# Altium schematic sheet PhantomPowerSupply_Schematic.SchDoc
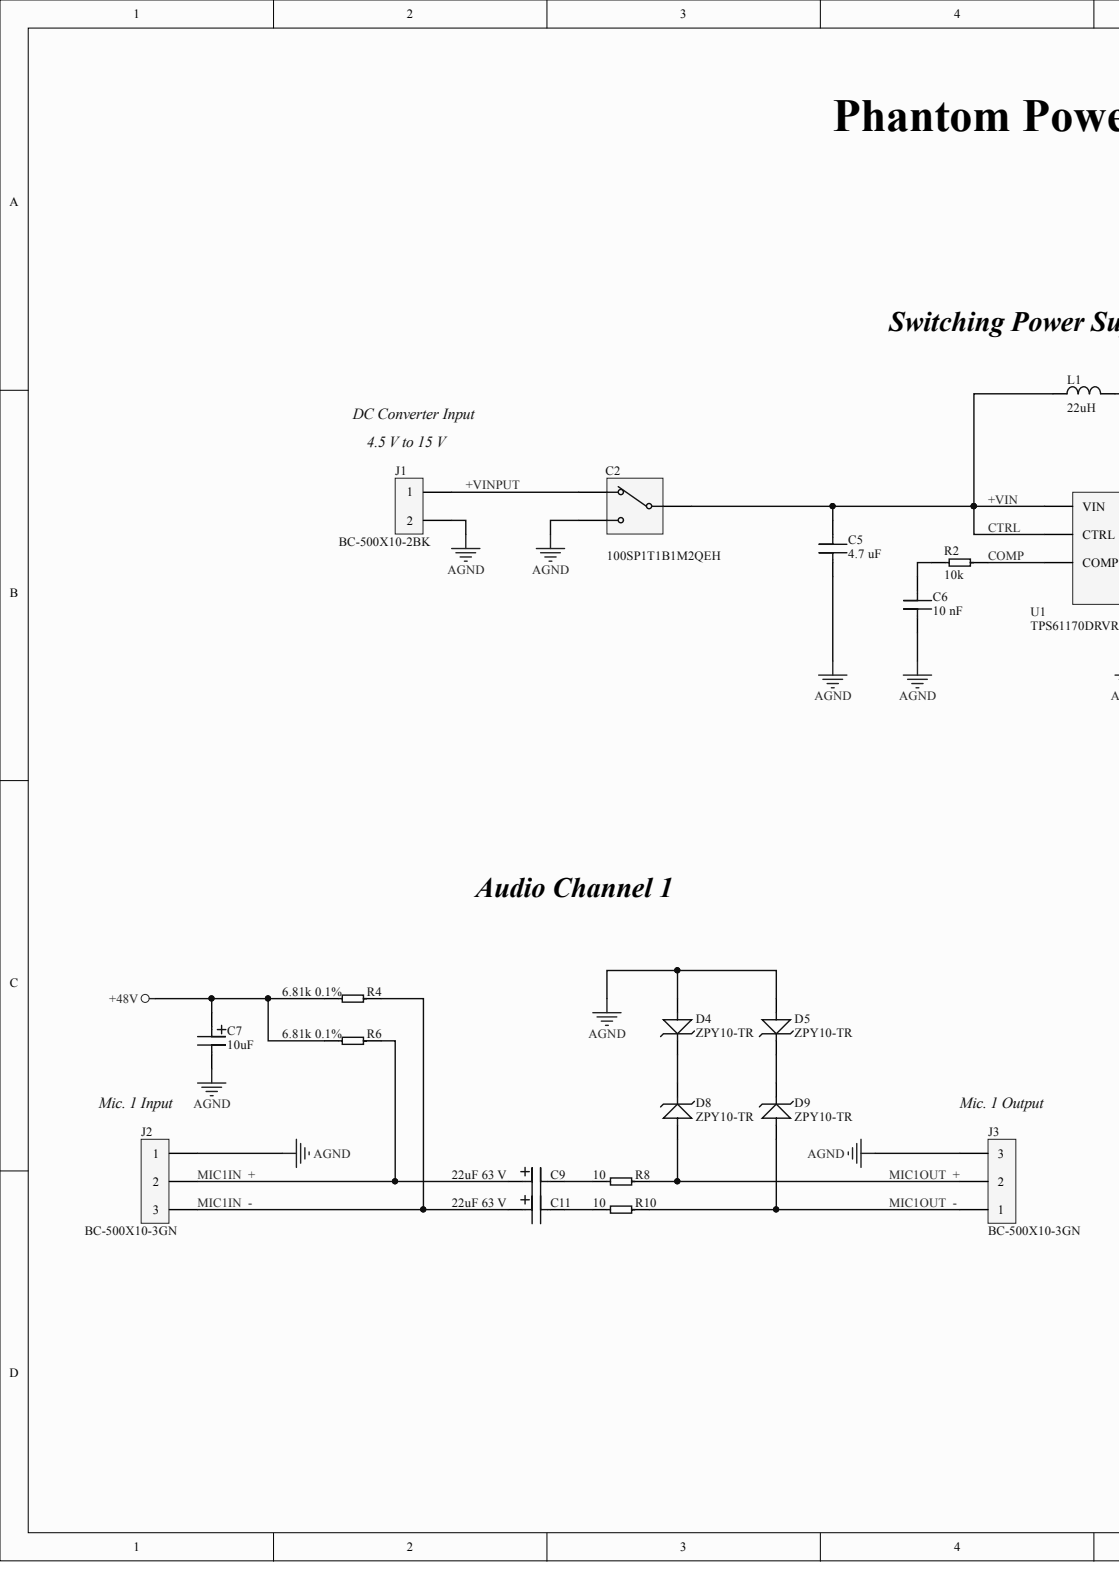
[diagram p0: PhantomPowerSupply_Schematic.SchDoc, left]
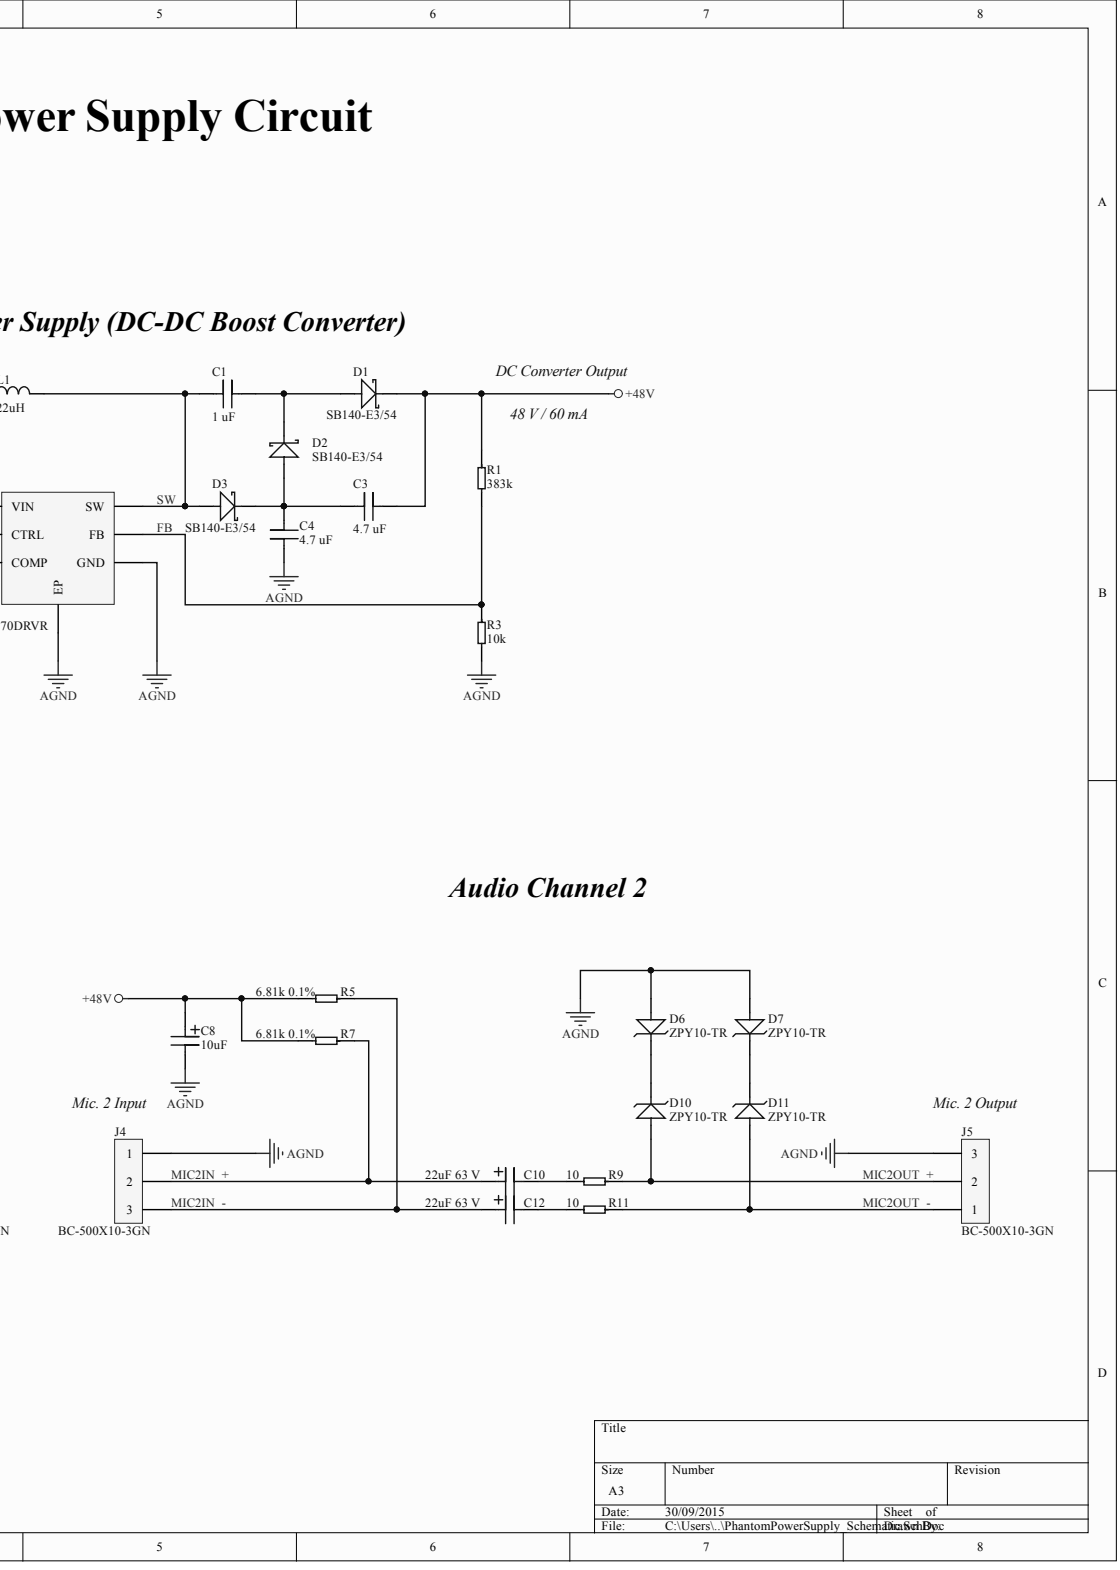
[diagram p1: PhantomPowerSupply_Schematic.SchDoc, right]

## Parts
C1  1 uF  [CRADIAL-CERAMIC-BROWN]
C2  100SP1T1B1M2QEH  [SPDT-M2]
C3  4.7 uF  [CRADIAL-CERAMIC]
C4  4.7 uF  [CRADIAL-CERAMIC]
C5  4.7 uF  [CRADIAL-CERAMIC]
C6  10 nF  [CRADIAL-CERAMIC-BROWN]
C7  10uF  [C-ELECTROLYTIC-RADIAL]
C8  10uF  [C-ELECTROLYTIC-RADIAL]
C9  22uF 63 V  Voltage Rating DC: 63 V  [C-ELECTROLYTIC-RADIAL]
C10  22uF 63 V  Voltage Rating DC: 63 V  [C-ELECTROLYTIC-RADIAL]
C11  22uF 63 V  Voltage Rating DC: 63 V  [C-ELECTROLYTIC-RADIAL]
C12  22uF 63 V  Voltage Rating DC: 63 V  [C-ELECTROLYTIC-RADIAL]
D1  SB140-E3/54  [D-SCHOTTKY-AXIAL]
D2  SB140-E3/54  [D-SCHOTTKY-AXIAL]
D3  SB140-E3/54  [D-SCHOTTKY-AXIAL]
D4  ZPY10-TR  [D-ZENER-AXIAL]
D5  ZPY10-TR  [D-ZENER-AXIAL]
D6  ZPY10-TR  [D-ZENER-AXIAL]
D7  ZPY10-TR  [D-ZENER-AXIAL]
D8  ZPY10-TR  [D-ZENER-AXIAL]
D9  ZPY10-TR  [D-ZENER-AXIAL]
D10  ZPY10-TR  [D-ZENER-AXIAL]
D11  ZPY10-TR  [D-ZENER-AXIAL]
J1  BC-500X10-2BK  [SCREW-CON-2POS]
J2  BC-500X10-3GN  [SCREW-CON-3POS]
J3  BC-500X10-3GN  [SCREW-CON-3POS]
J4  BC-500X10-3GN  [SCREW-CON-3POS]
J5  BC-500X10-3GN  [SCREW-CON-3POS]
L1  22uH  [L-AXIAL]
R1  383k  [R-AXIAL]
R2  10k  [R-AXIAL]
R3  10k  [R-AXIAL]
R4  6.81k 0.1%  [R-AXIAL]
R5  6.81k 0.1%  [R-AXIAL]
R6  6.81k 0.1%  [R-AXIAL]
R7  6.81k 0.1%  [R-AXIAL]
R8  10  [R-AXIAL]
R9  10  [R-AXIAL]
R10  10  [R-AXIAL]
R11  10  [R-AXIAL]
U1  TPS61170DRVR  [S-PWSON-N6]

## Nets
+48V: C3.2 C7.1 C8.1 D1.2 R1.2 R4.1 R5.1 R6.1 R7.1
AGND: C2.3 C4.1 C5.1 C6.1 C7.2 C8.2 D4.1 D5.1 D6.1 D7.1 J1.2 J2.1 J3.3 J4.1 J5.3 R3.1 U1.3(GND) U1.7(EP)
+VIN: C2.2 C5.2 L1.1 U1.5(CTRL) U1.6(VIN)
+VINPUT: C2.1 J1.1
COMP: R2.2 U1.2(COMP)
FB: R1.1 R3.2 U1.1(FB)
MIC1IN_+: C9.1 J2.2 R6.2
MIC1IN_-: C11.1 J2.3 R4.2
MIC1OUT_+: D8.1 J3.2 R8.2
MIC1OUT_-: D9.1 J3.1 R10.2
MIC2IN_+: C10.1 J4.2 R7.2
MIC2IN_-: C12.1 J4.3 R5.2
MIC2OUT_+: D10.1 J5.2 R9.2
MIC2OUT_-: D11.1 J5.1 R11.2
SW: C1.1 D3.1 L1.2 U1.4(SW)
NetC1_2: C1.2 D1.1 D2.2
NetC3_1: C3.1 C4.2 D2.1 D3.2
NetC6_2: C6.2 R2.1
NetC9_2: C9.2 R8.1
NetC10_2: C10.2 R9.1
NetC11_2: C11.2 R10.1
NetC12_2: C12.2 R11.1
NetD4_2: D4.2 D8.2
NetD5_2: D5.2 D9.2
NetD6_2: D6.2 D10.2
NetD7_2: D7.2 D11.2

## Library data of the parts
C1 = K105K20X7RF5TH5: Multilayer Ceramic Capacitors MLCC - Leaded 1uF 50volts 10% X7R 5mm LS; Brand: Vishay / BC Components; Case Style: Dipped; Lead Diameter: 0.5 mm; Voltage Rating: 50 V; Capacitance: 1 uF; Case Height: 5 mm; Case Length: 5 mm; Case Width: 5 mm; Category: Multilayer Ceramic Capacitors MLCC - Leaded; Component Kind: Standard; ComponentLink1Description: http://www.mouser.com/ds/2/427/mkseries-205465.pdf; ComponentLink1URL: http://www.mouser.com/ds/2/427/mkseries-205465.pdf; Lead Spacing: 5 mm; Lead Style: Outside Bend; Manufacturer: Vishay / BC Components; Manufacturer Part Number: K105K20X7RF5TH5; Maximum Operating Temperature: + 125 C; Minimum Operating Temperature: - 55 C; Minimum Order Quantity: 1; Operating Temperature Range: - 55 C to + 125 C; Packaging: Reel; Pricing 1: 1=0.312, 3000=0.166, 6000=0.150, 15000=0.141, 30000=0.131 (EUR); Product: General Type MLCCs; Product Category: Ceramic Capacitors; Reeling: True; RoHS: RoHS Compliant By Exemption; RoHS - Mouser: E; Series: K; Stock 1: 11553; Supplier 1: Mouser; Temperature Coefficient/Code: X7R; Termination Style: Radial; Tolerance: 10 %; Tradename: Mono-Kap; Voltage Rating DC: 50 V; Library Name: PhantomPowerSupply_SchematicLibrary.SchLib
C2 = 100SP1T1B1M2QEH: Toggle Switches SPDT ON-ON PC MNT; Actuator: Bat; Brand: E-Switch; Current Rating: 4 A; Illumination: None; Switch Function: ON - NONE - ON; Voltage Rating AC: 250 V; Voltage Rating DC: 28 V; Bushing Size: 1/4-40; Category: Toggle Switches; Component Kind: Standard; ComponentLink1Description: http://www.mouser.com/ds/2/140/100-346274.pdf; ComponentLink1URL: http://www.mouser.com/ds/2/140/100-346274.pdf; Contact Form: SPDT; Contact Plating: Silver; Manufacturer: E-Switch; Manufacturer Part Number: 100SP1T1B1M2QEH; Minimum Order Quantity: 1; Mounting Style: Through Hole; Pricing 1: 1=1.69, 10=1.62, 25=1.54, 50=1.48, 100=1.34, 250=1.23, 500=1.13 (EUR); Product Category: Toggle Switches; Reeling: False; RoHS: RoHS Compliant; RoHS - Mouser: Y; Series: 100; Stock 1: 1386; Supplier 1: Mouser; Terminal Seal: Sealed; Termination Style: Solder Pin; Library Name: PhantomPowerSupply_SchematicLibrary.SchLib
C3-C5 = FK11X7R1H475K: Multilayer Ceramic Capacitors MLCC - Leaded 4.7uF 50volts X7R +/-10%; Brand: TDK; Case Height: 4 mm; Case Length: 5.5 mm; Case Style: Dipped; Case Width: 7 mm; Lead Diameter: 0.5 mm; Tolerance: 10 %; Type: Dipped Radial Lead Commercial Grade; Voltage Rating: 50 V; Capacitance: 4.7 uF; Category: Multilayer Ceramic Capacitors MLCC - Leaded; Component Kind: Standard; ComponentLink1Description: http://www.mouser.com/ds/2/400/tdk_lowvoltageleadmlcc_commercial_general_en-347111.pdf; ComponentLink1URL: http://www.mouser.com/ds/2/400/tdk_lowvoltageleadmlcc_commercial_general_en-347111.pdf; Lead Spacing: 2.5 mm; Manufacturer: TDK; Manufacturer Part Number: FK11X7R1H475K; Maximum Operating Temperature: + 125 C; Minimum Operating Temperature: - 55 C; Minimum Order Quantity: 1; Operating Temperature Range: - 55 C to + 125 C; Packaging: Bulk; Pricing 1: 1=0.784, 10=0.602, 100=0.334, 1000=0.287, 2500=0.254, 10000=0.246, 25000=0.236, 50000=0.231 (EUR); Product: General Type MLCCs; Reeling: False; RoHS: RoHS Compliant; RoHS - Mouser: Y; Series: FK; Standard Pack Qty: 500; Stock 1: 12031; Supplier 1: Mouser; Temperature Coefficient/Code: X7R; Termination Style: Radial; Voltage Rating DC: 50 V; Library Name: PhantomPowerSupply_SchematicLibrary.SchLib
C6 = K103K15X7RF5UH5: Multilayer Ceramic Capacitors MLCC - Leaded .01uF 50volts 10% 5.0mm X7R; Brand: Vishay / BC Components; Capacitance: 0.01 uF; Case Style: Dipped; Case Width: 2.5 mm; Product Status: New at Mouser; Voltage Rating: 50 V; Category: Multilayer Ceramic Capacitors MLCC - Leaded; Component Kind: Standard; ComponentLink1Description: http://www.mouser.com/catalog/specsheets/mkseries.pdf; ComponentLink1URL: http://www.mouser.com/catalog/specsheets/mkseries.pdf; Manufacturer: Vishay / BC Components; Manufacturer Part Number: K103K15X7RF5UH5; Maximum Operating Temperature: + 125 C; Minimum Operating Temperature: - 55 C; Minimum Order Quantity: 1; Operating Temperature Range: - 55 C to + 125 C; Packaging: Ammo Pack; Pricing 1: 1=0.032, 100=0.024, 2500=0.024, 5000=0.016 (EUR); Product: General Type MLCCs; Product Category: Dipped Radial Ceramic Capacitor; Reeling: False; RoHS: RoHS Compliant; RoHS - Mouser: Y; Standard Pack Qty: 2500; Stock 1: 19645; Supplier 1: Mouser; Termination Style: Radial; Tolerance: 10 %; Voltage Rating DC: 50 V; Library Name: PhantomPowerSupply_SchematicLibrary.SchLib
C7 C8 = REA100M1HBK-0511P: Aluminium Electrolytic Capacitors - Leaded 50V 10uF 20% 5x11mm; Brand: Lelon; Case Diameter: 5 mm; Case Length: 11 mm; Dissipation Factor DF: 0.1; Load Life: 2000 h; Ripple Current: 65 mA; Capacitance: 10 uF; Category: Aluminium Electrolytic Capacitors - Leaded; Component Kind: Standard; ComponentLink1Description: http://www.mouser.com/catalog/specsheets/lelon_REA[1].pdf; ComponentLink1URL: http://www.mouser.com/catalog/specsheets/lelon_REA[1].pdf; Dimensions: 5 mm Dia. x 11 mm L; Lead Spacing: 2 mm; Manufacturer: Lelon; Manufacturer Part Number: REA100M1HBK-0511P; Maximum Operating Temperature: + 85 C; Minimum Order Quantity: 1; Pricing 1: 1=0.064, 10=0.062, 100=0.054, 500=0.041, 1000=0.039, 2000=0.032, 5000=0.028, 10000=0.025, 25000=0.017 (EUR); Product: General Purpose Electrolytic Capacitors; Product Category: Radial Electrolytic Capacitors; Reeling: False; RoHS: RoHS Compliant; RoHS - Mouser: Y; Series: REA; Standard Pack Qty: 500; Stock 1: 39187; Supplier 1: Mouser; Termination Style: Radial; Tolerance: 20 %; Voltage Rating DC: 50 V; Library Name: PhantomPowerSupply_SchematicLibrary.SchLib
C9-C12 = REA220M1JBK-0611P: Aluminium Electrolytic Capacitors - Leaded 63V 22uF 20% 6.3x11 mm; Brand: Lelon; Case Diameter: 6.3 mm; Case Length: 11 mm; Dissipation Factor DF: 0.09; Load Life: 2000 h; Product Status: Factory Special Order; Ripple Current: 115 mA; Capacitance: 22 uF; Category: Aluminium Electrolytic Capacitors - Leaded; Component Kind: Standard; ComponentLink1Description: http://www.mouser.com/catalog/specsheets/lelon_REA[1].pdf; ComponentLink1URL: http://www.mouser.com/catalog/specsheets/lelon_REA[1].pdf; Dimensions: 6.3 mm Dia. x 11 mm L; Lead Spacing: 2.5 mm; Manufacturer: Lelon; Manufacturer Part Number: REA220M1JBK-0611P; Maximum Operating Temperature: + 85 C; Minimum Order Quantity: 1; Packaging: Bulk; Pricing 1: 1=0.072, 10=0.066, 100=0.053, 500=0.040, 1000=0.038, 2000=0.033, 5000=0.027, 10000=0.024, 25000=0.022 (EUR); Product: General Purpose Electrolytic Capacitors; Product Category: Radial Electrolytic Capacitors; Reeling: False; RoHS: RoHS Compliant; RoHS - Mouser: Y; Series: REA; Standard Pack Qty: 1000; Stock 1: 9296; Supplier 1: Mouser; Termination Style: Radial; Tolerance: 20 %; Library Name: PhantomPowerSupply_SchematicLibrary.SchLib
D1-D3 = SB140-E3/54: Schottky Diodes & Rectifiers 40 Volt 1.0 Amp 50 Amp IFSM; Brand: Vishay Semiconductors; Configuration: Single; Forward Continuous Current: 1 A; Forward Voltage Drop: 0.48 V; Maximum Reverse Leakage Current: 500 uA; Max Surge Current: 50 A; Peak Reverse Voltage: 40 V; Category: Schottky Diodes & Rectifiers; Component Kind: Standard; ComponentLink1Description: http://www.mouser.com/ds/2/427/88715-108282.pdf; ComponentLink1URL: http://www.mouser.com/ds/2/427/88715-108282.pdf; Manufacturer: Vishay Semiconductors; Manufacturer Part Number: SB140-E3/54; Minimum Order Quantity: 1; Mounting Style: Through Hole; Operating Temperature Range: - 65 C to + 125 C; Package/Case: DO-204AL; Packaging: Reel; Pricing 1: 1=0.096, 5500=0.096 (EUR); Product: Schottky Diodes; Product Category: Schottky Rectifiers; Reeling: True; RoHS: RoHS Compliant By Exemption; RoHS - Mouser: E; Standard Pack Qty: 5500; Stock 1: 11660; Supplier 1: Mouser; Library Name: PhantomPowerSupply_SchematicLibrary.SchLib
D4-D11 = ZPY10-TR: Zener Diodes 10 Volt 1.3 Watt 5%; Brand: Vishay Semiconductors; Configuration: Single; Maximum Zener Impedance: 4 Ohms; Power Dissipation: 1.3 W; Voltage Temperature Coefficient: 0.07 %/C; Voltage Tolerance: 6 %; Zener Current: 105 mA; Zener Voltage: 10.005 V; Category: Zener Diodes; Component Kind: Standard; ComponentLink1Description: http://www.mouser.com/ds/2/427/zpy3v9-108163.pdf; ComponentLink1URL: http://www.mouser.com/ds/2/427/zpy3v9-108163.pdf; Manufacturer: Vishay Semiconductors; Manufacturer Part Number: ZPY10-TR; Maximum Operating Temperature: + 175 C; Minimum Operating Temperature: - 55 C; Minimum Order Quantity: 1; Mounting Style: Through Hole; Package/Case: DO-41; Packaging: Reel; Pricing 1: 1=0.080, 10=0.056, 100=0.055, 500=0.047, 1000=0.046, 5000=0.045, 10000=0.042, 25000=0.039 (EUR); Product Category: Zener Diodes; Reeling: True; RoHS: RoHS Compliant By Exemption; RoHS - Mouser: E; Standard Pack Qty: 5000; Stock 1: 17165; Supplier 1: Mouser; Library Name: PhantomPowerSupply_SchematicLibrary.SchLib
J1 = 5441537: Fixed Terminal Blocks BC-500X10- 2 BK; Brand: Phoenix Contact; Connection Method: Screw; Current Rating: 13.5 A; Number of Positions: 2; Pitch: 5 mm; Product Status: New Product; Voltage Rating: 400 V; Wire Gauge Max: 16; Wire Gauge Min: 26; Wire Gauge Range: 26-16; Category: Fixed Terminal Blocks; Color: Black; Component Kind: Standard; ComponentLink1Description: http://www.phoenixcontact.com/us/products/5441537/pdf; ComponentLink1URL: http://www.phoenixcontact.com/us/products/5441537/pdf; Contact Plating: Tin; Flammability Rating: UL 94 V-0; Housing Material: Polyamide (PA); Length: 5 mm; Manufacturer: Phoenix Contact; Manufacturer Part Number: 5441537; Minimum Order Quantity: 1; Mounting Method: -; Pricing 1: 1=0.704, 10=0.672, 25=0.664, 50=0.656, 100=0.614, 250=0.565, 500=0.522, 1000=0.499 (EUR); Reeling: False; RoHS: RoHS Compliant; RoHS - Mouser: Y; Series: BC; Standard Pack Qty: 100; Stock 1: 88; Supplier 1: Mouser; Termination Style: Through Hole; Tradename: BASICLINE; Library Name: PhantomPowerSupply_SchematicLibrary.SchLib
J2-J5 = 5442183: Fixed Terminal Blocks BC-500X10- 3 GN 5.00 MM PITCH; Brand: Phoenix Contact; Connection Method: Screw; Current Rating: 13.5 A; Number of Positions: 3; Pitch: 5 mm; Product Status: New Product; Voltage Rating: 400 V; Wire Gauge Range: 26-16; Wire Orientation: 0 deg; Category: Fixed Terminal Blocks; Color: Green; Component Kind: Standard; ComponentLink1Description: http://www.phoenixcontact.com/us/products/5442183/pdf; ComponentLink1URL: http://www.phoenixcontact.com/us/products/5442183/pdf; Contact Plating: Tin; Flammability Rating: UL 94 V-0; Manufacturer: Phoenix Contact; Manufacturer Part Number: 5442183; Minimum Order Quantity: 1; Pricing 1: 1=1.04, 10=1.00, 25=0.984, 50=0.976, 100=0.912, 250=0.840, 500=0.776, 1000=0.742 (EUR); Reeling: False; RoHS: RoHS Compliant; RoHS - Mouser: Y; Series: BC; Standard Pack Qty: 100; Stock 1: 314; Supplier 1: Mouser; Termination Style: Through Hole; Tradename: BASICLINE; Library Name: PhantomPowerSupply_SchematicLibrary.SchLib
L1 = 78F220J-RC: Fixed Inductors 22uH 5%; Brand: J.W. Miller; Case Diameter: 0.11 in; Case Length: 0.28 in; Core Material: Ferrite; Maximum DC Current: 285 mA; Maximum DC Resistance: 1.2 Ohms; Q Minimum: 50; Self Resonant Frequency: 13 MHz; Test Frequency: 2.5 MHz; Type: Conformal Coated Chokes; Category: Fixed Inductors; Component Kind: Standard; ComponentLink1Description: http://www.mouser.com/ds/2/54/78F_series-42602.pdf; ComponentLink1URL: http://www.mouser.com/ds/2/54/78F_series-42602.pdf; Inductance: 22 uH; Manufacturer: J.W. Miller; Manufacturer Part Number: 78F220J-RC; Minimum Order Quantity: 1; Operating Temperature Range: - 20 C to + 105 C; Pricing 1: 1=0.176, 10=0.138, 100=0.108, 500=0.092, 1000=0.078 (EUR); Product Category: Conformal Coated Chokes; Reeling: False; RoHS: RoHS Compliant; RoHS - Mouser: Y; Series: 78F; Standard Pack Qty: 5000; Stock 1: 8659; Supplier 1: Mouser; Termination Style: Axial; Tolerance: 5 %; Library Name: PhantomPowerSupply_SchematicLibrary.SchLib
R1 = MF1/4DCT26A3833F: Metal Film Resistors - Through Hole 1/4 WATT 383K OHM 1%; Brand: KOA Speer; Case Diameter: 2.3 mm; Case Length: 7.1 mm; Power Rating: 250 mW (1/4 W); Resistance: 383 kOhms; Temperature Coefficient: 100 PPM / C; Category: Metal Film Resistors - Through Hole; Component Kind: Standard; ComponentLink1Description: http://www.koaspeer.com/catimages/Products/MF-MFS-RK/MF-MFS-RK.pdf; ComponentLink1URL: http://www.koaspeer.com/catimages/Products/MF-MFS-RK/MF-MFS-RK.pdf; Lead Spacing: 30 mm; Manufacturer: KOA Speer; Manufacturer Part Number: MF1/4DCT26A3833F; Minimum Order Quantity: 1; Operating Temperature Range: - 55 C to + 155 C; Packaging: Ammo Pack; Pricing 1: 1=0.064, 100=0.032, 1000=0.022, 2000=0.022, 4000=0.016, 10000=0.012, 24000=0.009, 50000=0.006, 250000=0.005 (EUR); Product: Metal Film Resistors General Purpose; Product Category: 1/4Watt Metal Film Resistors; Reeling: False; RoHS: RoHS Compliant; RoHS - Mouser: Y; Series: MF; Standard Pack Qty: 2000; Stock 1: 5660; Supplier 1: Mouser; Termination Style: Axial; Tolerance: 1 %; Type: Semi-precision metal film resistors; Library Name: PhantomPowerSupply_SchematicLibrary.SchLib
R2 R3 = MF1/4DCT52A1002F: Metal Film Resistors - Through Hole 1/4 WATT 10K OHM 1%; Brand: KOA Speer; Case Diameter: 2.3 mm; Case Length: 7.1 mm; Power Rating: 250 mW (1/4 W); Resistance: 10 kOhms; Temperature Coefficient: 100 PPM / C; Category: Metal Film Resistors - Through Hole; Component Kind: Standard; ComponentLink1Description: http://www.koaspeer.com/catimages/Products/MF-MFS-RK/MF-MFS-RK.pdf; ComponentLink1URL: http://www.koaspeer.com/catimages/Products/MF-MFS-RK/MF-MFS-RK.pdf; Manufacturer: KOA Speer; Manufacturer Part Number: MF1/4DCT52A1002F; Minimum Order Quantity: 1; Packaging: Ammo Pack; Pricing 1: 1=0.064, 100=0.032, 1000=0.022, 5000=0.016, 10000=0.012, 25000=0.009, 50000=0.006, 250000=0.005 (EUR); Product: Metal Film Resistors General Purpose; Product Category: 1/4Watt Metal Film Resistors; Reeling: False; RoHS: RoHS Compliant; RoHS - Mouser: Y; Standard Pack Qty: 5000; Stock 1: 62471; Supplier 1: Mouser; Termination Style: Axial; Tolerance: 1 %; Library Name: PhantomPowerSupply_SchematicLibrary.SchLib
R4-R7 = RN55E6811BRE6: Metal Film Resistors - Through Hole 1/10watt 6.81Kohms .1% 25ppm; Brand: Vishay / Dale; Case Diameter: 2.29 mm; Case Length: 6.1 mm; Lead Diameter: 0.64 mm; Power Rating: 125 mW (1/8 W); Temperature Coefficient: 25 PPM / C; Voltage Rating: 200 V; Category: Metal Film Resistors - Through Hole; Component Kind: Standard; ComponentLink1Description: http://www.vishay.com/doc?31027; ComponentLink1URL: http://www.vishay.com/doc?31027; Manufacturer: Vishay / Dale; Manufacturer Part Number: RN55E6811BRE6; Minimum Order Quantity: 1; Operating Temperature Range: - 65 C to + 175 C; Packaging: Reel; Pricing 1: 1=0.416, 50=0.376, 100=0.320, 500=0.304, 1000=0.288, 2000=0.240, 5000=0.216 (EUR); Product: Precision Metal Film Resistors; Product Category: Metal Film Resistors; Reeling: True; Resistance: 6.81 kOhms; RoHS: No; RoHS - Mouser: N; Series: RN; Standard Pack Qty: 1000; Stock 1: 823; Supplier 1: Mouser; Termination Style: Axial; Tolerance: 0.1 %; Type: Military Precision Metal Film Resistors; Library Name: PhantomPowerSupply_SchematicLibrary.SchLib
R8-R11 = FRM-50JT-52-10R: Metal Film Resistors - Through Hole 10 OHM 1/2W 5%; Brand: Yageo; Case Diameter: 3.3 mm; Case Length: 9 mm; Lead Diameter: 0.55 mm; Power Rating: 500 mW (1/2 W); Resistance: 10 Ohms; Temperature Coefficient: 200 PPM / C; Voltage Rating: 250 V; Category: Metal Film Resistors - Through Hole; Component Kind: Standard; ComponentLink1Description: http://www.mouser.com/ds/2/447/Leaded-R_2011-336584.pdf; ComponentLink1URL: http://www.mouser.com/ds/2/447/Leaded-R_2011-336584.pdf; Manufacturer: Yageo; Manufacturer Part Number: FRM-50JT-52-10R; Minimum Order Quantity: 1; Operating Temperature Range: - 55 C to + 155 C; Packaging: Ammo Pack; Pricing 1: 1=0.032, 1000=0.024, 2000=0.017, 5000=0.015, 25000=0.014, 100000=0.013 (EUR); Product: Metal Film Resistors Flameproof; Product Category: Metal Film Resistors; Reeling: False; RoHS: RoHS Compliant; RoHS - Mouser: Y; Series: FRM; Stock 1: 3950; Supplier 1: Mouser; Termination Style: Axial; Tolerance: 5 %; Type: Fusible and Flame Proof Type Normal and Miniature Style; Library Name: PhantomPowerSupply_SchematicLibrary.SchLib
U1 = TPS61170DRVR: Voltage Regulators - Switching Regulators 1.2A Sw Hi Vltg Boost Conv; Brand: Texas Instruments; Input Voltage MAX: 18 V; Number of Outputs: 1 Output; Output Voltage: 3 V to 38 V; Switching Frequency: 1.2 MHz; Category: Voltage Regulators - Switching Regulators; Component Kind: Standard; ComponentLink1Description: http://www.mouser.com/ds/2/405/slvs789c-118222.pdf; ComponentLink1URL: http://www.mouser.com/ds/2/405/slvs789c-118222.pdf; Manufacturer: Texas Instruments; Manufacturer Part Number: TPS61170DRVR; Minimum Order Quantity: 1; Mounting Style: SMD/SMT; Output Current: 1.2 A; Package/Case: WSON-6; Packaging: Reel; Pricing 1: 1=2.42, 10=2.16, 25=1.94, 50=1.86, 100=1.77, 250=1.59, 500=1.43, 750=1.30, 1000=1.25, 3000=1.25 (EUR); Product Category: Converters - DC/DC; Reeling: True; RoHS: RoHS Compliant; RoHS - Mouser: Y; Series: TPS61170; Standard Pack Qty: 3000; Stock 1: 12732; Supplier 1: Mouser; Type: Step-Down Regulator; Library Name: PhantomPowerSupply_SchematicLibrary.SchLib
